# Altium schematic sheet Upstream.SchDoc(Upstream)
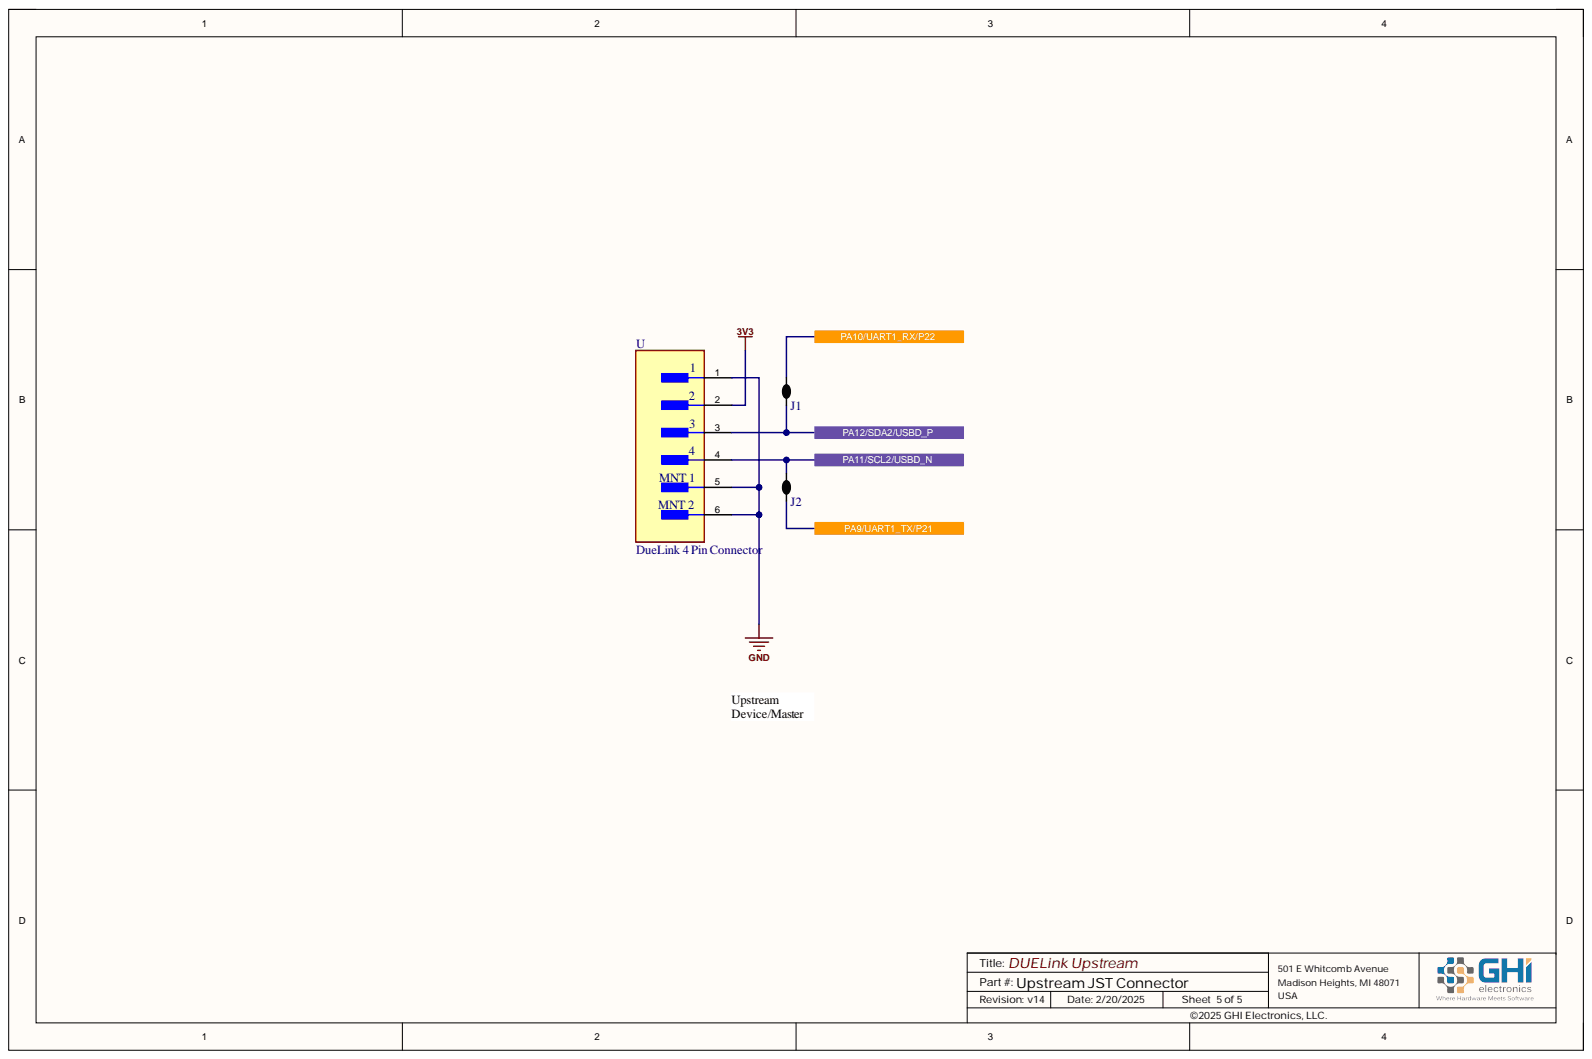
[diagram p0: Upstream.SchDoc]

## Parts
J1  2 way net tie junction  [2way_LP]  (value not drawn)
J2  2 way net tie junction  [2way_LP]  (value not drawn)
U  DueLink 4 Pin Connector  [DUELink 4 pin connector]

## Ports
PA9/UART1_TX/P21, PA10/UART1_RX/P22, PA11/SCL2/USBD_N, PA12/SDA2/USBD_P

## Nets
3V3: U.2
GND: U.1 U.5 U.6
PA9/UART1_TX/P21: J2.1 ; port PA9/UART1_TX/P21
PA10/UART1_RX/P22: J1.2 ; port PA10/UART1_RX/P22
PA11/SCL2/USBD_N: J2.2 U.4 ; port PA11/SCL2/USBD_N
PA12/SDA2/USBD_P: J1.1 U.3 ; port PA12/SDA2/USBD_P

## Library data of the parts
J1 J2 = 2way: Component Kind: Net Tie; ComponentLink1Description: Source; ComponentLink1URL: http://www.edatools.cz/addons; Description: 2 way net tie junction; FootprintNote: LP=12mil, MP=40mil, HP=120mil; Library Name: NetTieLib.IntLib
U = DueLink 4 Pin Connector: CONN HEADER SMD 4POS 1MM; Mated Stacking Heights: 4.9mm; Number of Positions: 4; Pitch: 0.039inch; Voltage Rating: 50V; Color: Natural; Component Kind: Standard; Connector Type: Header; Contact Finish - Mating: TIN; Contact Material: Copper Alloy; Contact Plating: Tin; Contact Shape: Square; Contact Type: Male Pin; Fastening Type: Pull; Flammability Rating: UL94 V-0; Insulation Color: Natural; Insulation Material: Nylon; Lead Free: Lead Free; Mount: Surface Mount; Number of Rows: 1; Package Quantity: 1; Packaging: Cut Tape; Series: SR; Termination: Solder; Library Name: GHI-Connectors.SchLib
